# Altium schematic sheet Base_Module.SchDoc
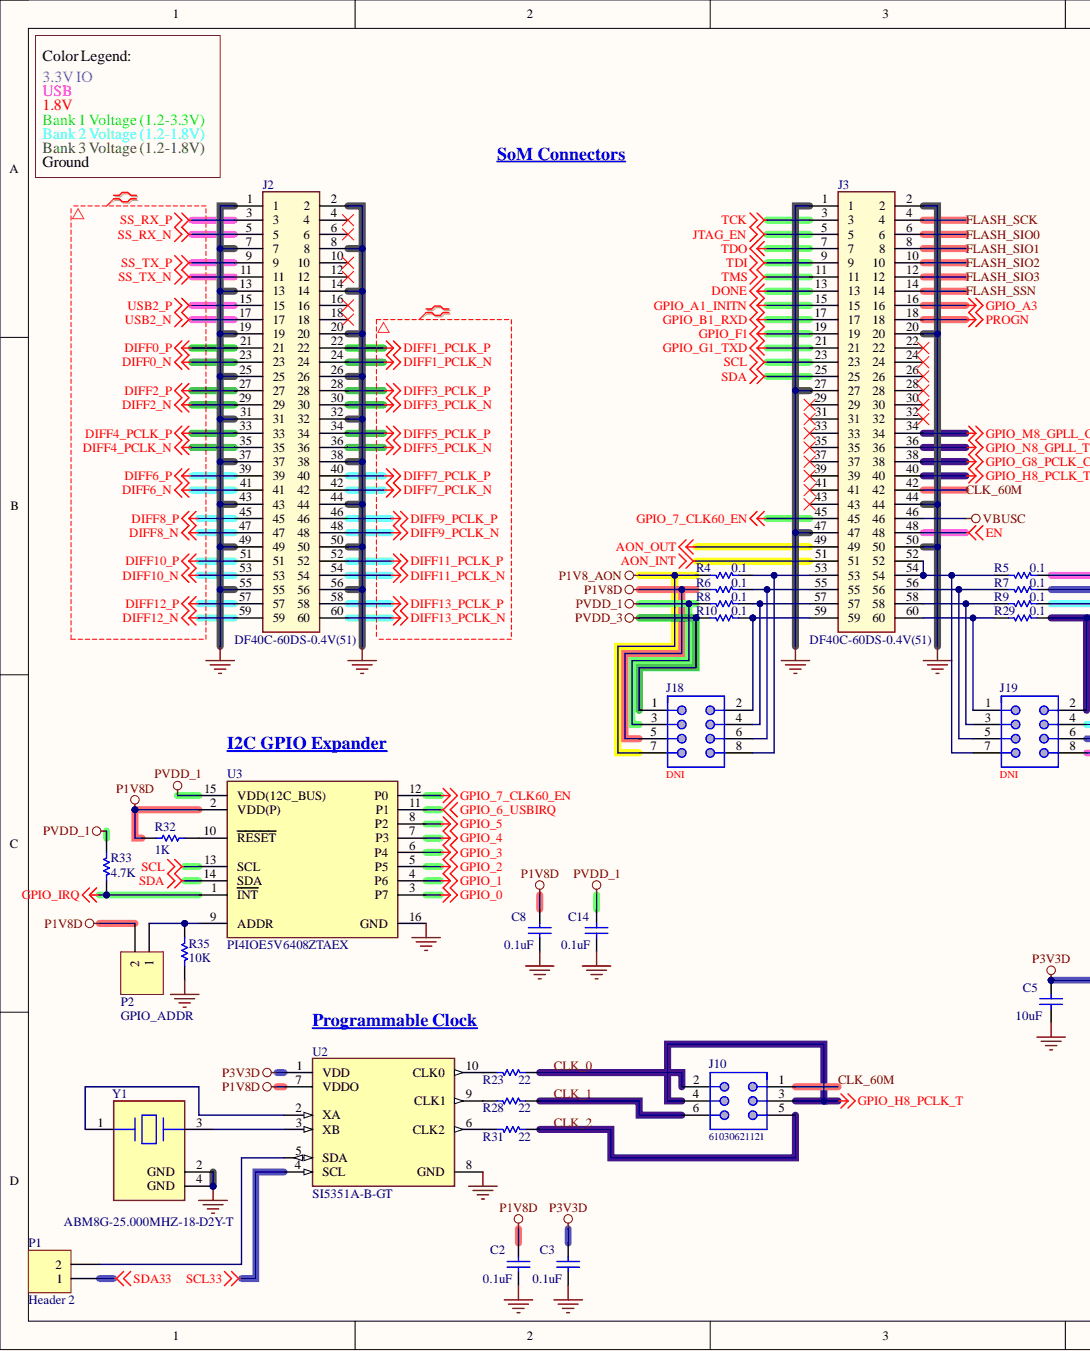
[diagram p0: Base_Module.SchDoc, left]
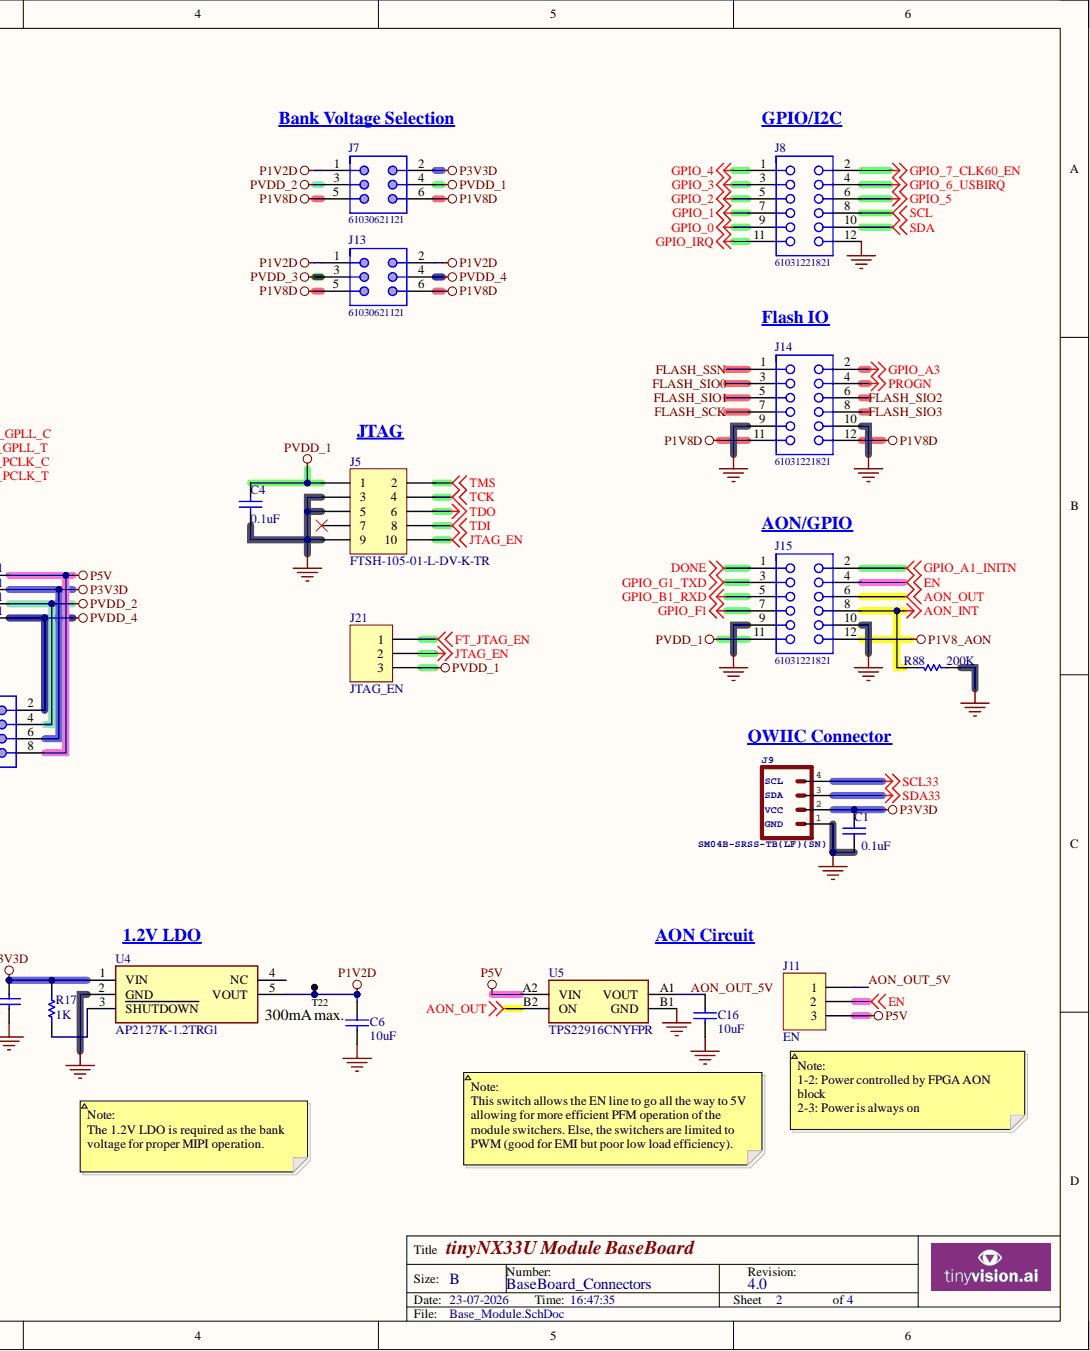
[diagram p1: Base_Module.SchDoc, right]

## Parts
C1  0.1uF  [CAPC1005X55USR]
C2  0.1uF  [CAPC0603X33N]
C3  0.1uF  [CAPC0603X33N]
C4  0.1uF  [CAPC1005X55USR]
C5  10uF  [CAPC1005X55USR]
C6  10uF  [CAPC1005X55USR]
C8  0.1uF  [CAPC0603X33N]
C14  0.1uF  [CAPC0603X33N]
C16  10uF  [CAPC1005X55USR]
J2  DF40C-60DS-0.4V(51)  [DF40C60DS04V51]
J3  DF40C-60DS-0.4V(51)  [DF40C60DS04V51]
J5  FTSH-105-01-L-DV-K-TR  [FTSH-105-01-XXX-DV-K]
J7  61030621121  [HDR3X2_MALE_2.54MM_WE61030621121]
J8  61031221821  [HDR6X2_MALE_2.54MM_WE61031221821]
J9  SM04B-SRSS-TB(LF)(SN)  [JST_SM04B-SRSS-TB(LF)(SN)]
J10  61030621121  [HDR3X2_MALE_2.54MM_WE61030621121]
J11  Content: EN  [HDR3X1_MALE_2.54MM]  (hidden comment: Header 3X1_2.54MM)
J13  61030621121  [HDR3X2_MALE_2.54MM_WE61030621121]
J14  61031221821  [HDR6X2_MALE_2.54MM_WE61031221821]
J15  61031221821  [HDR6X2_MALE_2.54MM_WE61031221821]
J18  DNI  [HDR4X2_MALE_2.54MM_WE61300821121]
J19  DNI  [HDR4X2_MALE_2.54MM_WE61300821121]
J21  Content: JTAG_EN  [HDR3X1_MALE_2.54MM]  (hidden comment: Header 3X1_2.54MM)
P1  Header 2  [HDR1X2]
P2  GPIO_ADDR  [HDR1X2]
R4  0.1  [RESC1005X40USR]
R5  0.1  [RESC1005X40USR]
R6  0.1  [RESC1005X40USR]
R7  0.1  [RESC1005X40USR]
R8  0.1  [RESC1005X40USR]
R9  0.1  [RESC1005X40USR]
R10  0.1  [RESC1005X40USR]
R17  1K  [RESC1005X40USR]
R23  22  [RESC1005X40USR]
R28  22  [RESC1005X40USR]
R29  0.1  [RESC1005X40USR]
R31  22  [RESC1005X40USR]
R32  1K  [RESC1005X40USR]
R33  4.7K  [RESC1005X40USR]
R35  10K  [RESC1005X40USR]
R88  200K  [RESC1005X40USR]
T22  TP_S  [TP_TH]  (value not drawn)  (no BOM)
U2  SI5351A-B-GT  [10MSOP_3X3_SIL]
U3  PI4IOE5V6408ZTAEX  [PI4IOE5V6408ZTAEX]  (value not drawn)
U4  AP2127K-1.2TRG1  [SOT95P282X145-5N]
U5  TPS22916CNYFPR  [BGA4C40P2X2_78X78X50]
Y1  ABM8G-25.000MHZ-18-D2Y-T  [XTAL_ABM8G3225X10]

## Nets
AON_INT: J3.51 J15.8 R88.2
AON_OUT: J3.49 J15.6 U5.B2(ON)
DIFF0_N: J2.23
DIFF0_P: J2.21
DIFF1_PCLK_N: J2.24
DIFF1_PCLK_P: J2.22
DIFF2_N: J2.29
DIFF2_P: J2.27
DIFF3_PCLK_N: J2.30
DIFF3_PCLK_P: J2.28
DIFF4_PCLK_N: J2.35
DIFF4_PCLK_P: J2.33
DIFF5_PCLK_N: J2.36
DIFF5_PCLK_P: J2.34
DIFF6_N: J2.41
DIFF6_P: J2.39
DIFF7_PCLK_N: J2.42
DIFF7_PCLK_P: J2.40
DIFF8_N: J2.47
DIFF8_P: J2.45
DIFF9_PCLK_N: J2.48
DIFF9_PCLK_P: J2.46
DIFF10_N: J2.53
DIFF10_P: J2.51
DIFF11_PCLK_N: J2.54
DIFF11_PCLK_P: J2.52
DIFF12_N: J2.59
DIFF12_P: J2.57
DIFF13_PCLK_N: J2.60
DIFF13_PCLK_P: J2.58
DONE: J3.13 J15.1
EN: J3.48 J11.2 J15.4
FT_JTAG_EN: J21.1
GND: C1.1 C2.1 C3.1 C4.1 C5.1 C6.1 C8.1 C14.1 C16.1 J2.1 J2.2 J2.7 J2.8 J2.13 J2.14 J2.19 J2.20 J2.25 J2.26 J2.31 J2.32 J2.37 J2.38 J2.43 J2.44 J2.49 J2.50 J2.55 J2.56 J3.1 J3.2 J3.20 J3.27 J3.44 J3.47 J3.50 J5.3 J5.5 J5.9 J8.12 J9.1 J14.9 J14.10 J15.9 J15.10 R35.1 R88.1 U2.8(GND) U3.16(GND) U4.2(GND) U5.B1(GND) Y1.2(GND) Y1.4(GND)
GPIO_0: J8.9 U3.3(P7)
GPIO_1: J8.7 U3.4(P6)
GPIO_2: J8.5 U3.5(P5)
GPIO_3: J8.3 U3.6(P4)
GPIO_4: J8.1 U3.7(P3)
GPIO_5: J8.6 U3.8(P2)
GPIO_6_USBIRQ: J8.4 U3.11(P1)
GPIO_7_CLK60_EN: J3.45 J8.2 U3.12(P0)
GPIO_A1_INITN: J3.15 J15.2
GPIO_A3: J3.16 J14.2
GPIO_B1_RXD: J3.17 J15.5
GPIO_F1: J3.19 J15.7
GPIO_G1_TXD: J3.21 J15.3
GPIO_G8_PCLK_C: J3.38
GPIO_H8_PCLK_T: J3.40 J10.3 J10.4
GPIO_IRQ: J8.11 R33.2 U3.1(INT)
GPIO_M8_GPLL_C: J3.34
GPIO_N8_GPLL_T: J3.36
JTAG_EN: J3.5 J5.10 J21.2
P1V2D: C6.2 J7.1 J13.1 J13.2 T22.1 U4.5(VOUT)
P1V8_AON: J15.12 J18.7 R4.2
P1V8D: C2.2 C8.2 J7.5 J7.6 J13.5 J13.6 J14.11 J14.12 J18.5 P2.2 R6.2 R32.1 U2.7(VDDO) U3.2(VDD(P))
P3V3D: C1.2 C3.2 C5.2 J7.2 J9.2 J19.6 R7.1 R17.1 U2.1(VDD) U4.1(VIN)
P5V: J11.3 J19.8 R5.1 U5.A2(VIN)
PROGN: J3.18 J14.4
PVDD_1: C4.2 C14.2 J5.1 J7.4 J15.11 J18.3 J21.3 R8.2 R33.1 U3.15(VDD(12C_BUS))
PVDD_2: J7.3 J19.4 R9.1
PVDD_3: J13.3 J18.1 R10.2
PVDD_4: J13.4 J19.2 R29.1
SCL: J3.23 J8.8 U3.13(SCL)
SCL33: J9.4 U2.4(SCL)
SDA: J3.25 J8.10 U3.14(SDA)
SDA33: J9.3 P1.1
SS_RX_N: J2.5
SS_RX_P: J2.3
SS_TX_N: J2.11
SS_TX_P: J2.9
TCK: J3.3 J5.4
TDI: J3.9 J5.8
TDO: J3.7 J5.6
TMS: J3.11 J5.2
USB2_N: J2.17
USB2_P: J2.15
VBUSC: J3.46
AON_OUT_5V: C16.2 J11.1 U5.A1(VOUT)
CLK_0: J10.2 R23.1
CLK_1: J10.6 R28.1
CLK_2: J10.5 R31.1
CLK_60M: J3.42 J10.1
FLASH_SCK: J3.4 J14.7
FLASH_SIO0: J3.6 J14.3
FLASH_SIO1: J3.8 J14.5
FLASH_SIO2: J3.10 J14.6
FLASH_SIO3: J3.12 J14.8
FLASH_SSN: J3.14 J14.1
NetJ3_52: J3.52 J3.54 J19.7 R5.2
NetJ3_53: J3.53 J18.8 R4.1
NetJ3_55: J3.55 J18.6 R6.1
NetJ3_56: J3.56 J19.5 R7.2
NetJ3_57: J3.57 J18.4 R8.1
NetJ3_58: J3.58 J19.3 R9.2
NetJ3_59: J3.59 J18.2 R10.1
NetJ3_60: J3.60 J19.1 R29.2
NetP1_2: P1.2 U2.5(SDA)
NetP2_1: P2.1 R35.2 U3.9(ADDR)
NetR17_2: R17.2 U4.3(SHUTDOWN)
NetR23_2: R23.2 U2.10(CLK0)
NetR28_2: R28.2 U2.9(CLK1)
NetR31_2: R31.2 U2.6(CLK2)
NetR32_2: R32.2 U3.10(RESET)
NetU2_2: U2.2(XA) Y1.1
NetU2_3: U2.3(XB) Y1.3

Not connected: J2 4 6 10 12 16 18; J3 22 24 26 28 29 30 31 32 33 35 37 39 41 43; J5 7; U4 4(NC)

## Library data of the parts
C1 C4 = CAP CER 0.1UF 16V X7R 0402: Manufacturer: TDK Corporation; Manufacturer Part Number: CGA2B1X7R1C104K050BC; Component Kind: Standard; Description: CAP CER 0.1UF 16V X7R 0402; Height: 0.55mm; Library Name: NX Module baseboard.SCHLIB; Package: 0402; Supplier 1: Digi-Key; Supplier Part Number 1: 445-5613-2-ND; value: 0.1uF
C2 C3 C8 C14 = CAP CER 0.1UF 10V X7S 0201: CAP CER 0.1UF 10V X5R 0201; Manufacturer: Murata Electronics; Manufacturer Part Number: GRM033R61A104KE15D; Component Kind: Standard; Height: 0.33mm; Library Name: Smart Camera v2.SCHLIB; Package: 0201; Supplier 1: Digi-Key; Supplier Part Number 1: 490-5881-1-ND; value: 0.1uF
C5 C6 C16 = Cap_10uF_X5R_20%_10V_0402: CAP CER 10UF 10V X5R 0402; Manufacturer: Samsung Electro-Mechanics; Manufacturer Part Number: CL05A106MP5NUNC; Part #: E-CAP-042; Component Kind: Standard; ComponentLink1Description: New link; Height: 0.55mm; Package: 0402; Supplier 1: Digi-Key; Supplier Part Number 1: 1276-1450-1-ND; value: 10uF; Library Name: tinyCLUNX33_Compute.SCHLIB
J2 J3 = DF40C-60DS-0.4V(51): CONN RCPT 60POS SMD GOLD; Manufacturer: Hirose; Connector Type: Receptacle; Current Rating: 300mA; Mated Stacking Heights: 1.5mm; Number of Contacts: 60; Pitch: 0.4mm; Voltage Rating: 30V; Category: Headers and Wire Housings; Component Kind: Standard; ComponentLink1Description: https://datasheet.ciiva.com/46408/1824826-46408909.pdf?src-supplier=Element14; ComponentLink1URL: https://datasheet.ciiva.com/46408/1824826-46408909.pdf?src-supplier=Element14; Contact Material: Bronze; Contact Plating: Gold; Gender: Receptacle; Housing Material: Polymer; Lead Free: Lead Free; Library Name: NX Module baseboard.SCHLIB; Manufacturer Part Number: DF40C-60DS-0.4V(51); Mounting Technology: Surface Mount; Number of Positions: 60; Number of Rows: 2; Package Quantity: 5000; Packaging: Tape and Reel; Pricing: 1=1.29000, 10=1.08900, 25=1.04440, 50=1.02220, 100=0.97770, 250=0.88880, 500=0.79992, 1000=0.71104, 2500=0.64438 (USD); Reach SVHC Compliant: No; RoHS: Yes; RoHS Compliant: Yes; Series: DF40; Stock: 11621; Supplier 1: Digi-Key; Supplier Part Number 1: 26-DF40C-60DS-0.4V(51)CT-ND; Termination: SMD/SMT
J5 = HDR2X5_1.27mm: CONN HEADER SMD 10POS 1.27MM; Manufacturer: Samtec Inc.; Connector Type: Male Pin; Current Rating: 1.75A; Gender: Male; Number of Contacts: 10; Row Spacing: 0.05inch; Category: Headers and Wire Housings; Color: Black; Component Kind: Standard; ComponentLink1Description: https://datasheet.ciiva.com/10245/ftsh-mt-10245289.pdf?src-supplier=Avnet; ComponentLink1URL: https://datasheet.ciiva.com/10245/ftsh-mt-10245289.pdf?src-supplier=Avnet; ComponentLink2Description: https://datasheet.ciiva.com/739/ftsh-1xx-xx-xxx-dv-xxx-mkt-739005.pdf?src-supplier=Digi-Key; ComponentLink2URL: https://datasheet.ciiva.com/739/ftsh-1xx-xx-xxx-dv-xxx-mkt-739005.pdf?src-supplier=Digi-Key; ComponentLink3Description: https://datasheet.ciiva.com/23735/81359-23735917.pdf?src-supplier=Newark; ComponentLink3URL: https://datasheet.ciiva.com/23735/81359-23735917.pdf?src-supplier=Newark; Contact Material: Bronze; Contact Mating Length: 0.12inch; Contact Plating: Gold; Contact Type: Male Pin; Depth: 3.43mm; Housing Color: Black; Housing Material: Polymer; Lead Free: Lead Free; Length: 6.35mm; Library Name: tinyAI.SCHLIB; Manufacturer Part Number: FTSH-105-01-L-DV-K-TR; Max Current Rating: 1.75A; Max Operating Temperature: 125°C; Min Operating Temperature: -55°C; Mount: Surface Mount; Number of Positions: 10; Number of Rows: 2; Orientation: Straight; Package Quantity: 550; Packaging: Tape and Reel; Pitch: 1.27mm; Pricing 1: 1=4.28000, 10=3.88500, 100=3.42300 (USD); Radiation Hardening: No; REACH SVHC: No SVHC; RoHS: Yes; RoHS Compliant: Yes; Series: FTSH; Stock 1: 18878; Supplier 1: Digi-Key; Supplier Part Number 1: SAM13165CT-ND; Termination: Solder
J7 J10 J13 = Header 3X2_SMD_61030621121: SMT Vertical Pin Header WR-PHD, Pitch 2.54 mm, Dual Row, 6 pins; Manufacturer: Wurth Electronics; Contact Resistance: 20mR; Current Rating: 3A; Gender: Male; Number of Contacts: 6; Operating Supply Voltage: 250V; Pitch: 0.1inch; Category: Headers and Wire Housings; Color: Black; Component Kind: Standard; ComponentLink1Description: https://datasheet.ciiva.com/28505/1737273-28505153.pdf?src-supplier=Element14; ComponentLink1URL: https://datasheet.ciiva.com/28505/1737273-28505153.pdf?src-supplier=Element14; Connector Type: Header; Contact Finish - Mating: GOLD; Contact Material: Copper Alloy,Copper; Contact Mating Length: 0.236inch; Contact Plating: Gold; Contact Shape: Square; Contact Type: Male Pin; Fastening Type: Pull; Flammability Rating: UL94 V-0; Height: 10mm; Insulation Color: Black; Insulation Material: Nylon; Library Name: NX Module baseboard.SCHLIB; Manufacturer Part Number: 61030621121; Max Operating Temperature: 125°C; Min Operating Temperature: -40°C; Mount: Surface Mount; Number of Positions: 6; Number of Rows: 2; Orientation: 5495OrientationType; Packaging: Cut Tape; Pricing 1: 1=1.84000, 10=1.55900, 25=1.30000, 50=1.08400, 100=0.98500 (USD); Reach SVHC Compliant: Unknown; RoHS: Yes; RoHS Compliant: Yes; Row Spacing: 0.1inch; Series: WR-PHD; Stock 1: 2240; Supplier 1: Digikey; Supplier Part Number 1: 732-61030621121CT-ND; Termination: Solder; Voltage Rating: 250V
J8 J14 J15 = Header 6X2_SMD_WE61031221821: Connector, RCPT, 12POS, 2ROW, 2.54mm;; Manufacturer: Wurth Electronics; Connector Type: Header; Number of Positions: 12; Voltage Rating (AC): 250V; Category: Connectors; Component Kind: Standard; Contact Finish - Mating: GOLD; Contact Material: Copper Alloy; Contact Shape: Square; Contact Type: Female Socket; Fastening Type: Pull; Flammability Rating: UL94 V-0; Insulation Color: Black; Insulation Material: Nylon; Library Name: NX Module baseboard.SCHLIB; Manufacturer Part Number: 61031221821; Max Operating Temperature: 105°C; Min Operating Temperature: -40°C; Mount: Surface Mount; Number of Rows: 2; Pricing 1: 1=3.07000, 10=2.85700, 50=2.55400 (USD); RoHS: Yes; RoHS Compliant: Yes; Series: WR-PHD; Stock 1: 530; Supplier 1: Digikey; Supplier Part Number 1: 732-13383-1-ND; Termination: Solder
J9 = QWIIC_CONNECTORJS-1MM: Connector Header, Side Entry, SR Series, 4 Position, 1.00mm, 50V, Surface Mount, Tin, Natural; Manufacturer: JST; Contact Resistance: 40mR; Max Current Rating: 1A; Number of Contacts: 4; Pitch: 1mm; Category: Connectors; Component Kind: Standard; ComponentLink1Description: https://datasheet.ciiva.com/26894/getdatasheetpartid-640736-26894676.pdf?src-supplier=Verical; ComponentLink1URL: https://datasheet.ciiva.com/26894/getdatasheetpartid-640736-26894676.pdf?src-supplier=Verical; ComponentLink2Description: https://datasheet.ciiva.com/26894/getdatasheetpartid-499363-26894945.pdf?src-supplier=Verical; ComponentLink2URL: https://datasheet.ciiva.com/26894/getdatasheetpartid-499363-26894945.pdf?src-supplier=Verical; ComponentLink3Description: https://datasheet.ciiva.com/16487/jstsm04b-srss-tb-lf-sn-16487222.pdf?src-supplier=Future+Electronics; ComponentLink3URL: https://datasheet.ciiva.com/16487/jstsm04b-srss-tb-lf-sn-16487222.pdf?src-supplier=Future+Electronics; Connector Type: Header; Contact Finish - Mating: TIN; Contact Material: Copper Alloy; Contact Plating: Copper,Tin; Contact Shape: Square; Contact Type: Male Pin; Depth: 4.95mm; Fastening Type: Pull; Flammability Rating: UL94 V-0; GND: GND; Housing Color: Beige; Housing Material: Polyamide; Insulation Color: Natural; Insulation Material: Nylon; Lead Free: Lead Free; Length: 6mm; Library Name: NX Module baseboard.SCHLIB; Manufacturer Part Number: SM04B-SRSS-TB(LF)(SN); Max Operating Temperature: 85°C; Max Voltage Rating (AC): 50V; Max Voltage Rating (DC): 50V; Min Operating Temperature: -25°C; Mount: Surface Mount; Number of Positions: 4; Number of Rows: 1; Orientation: Right Angle; Packaging: Tape and Reel; Pricing 1: 1=0.141000, 10=0.141000, 100=0.141000, 500=0.141000, 1000=0.141000 (USD); RoHS: Yes; SCL: SCL; SDA: SDA; Series: SR; Stock 1: 176593; Supplier 1: Newark; Supplier Part Number 1: 87R0314; Termination: Solder; VCC: VCC; Voltage Rating: 50V
J11 J21 = Header 3X1_2.54MM: CONN HEADER .100 SNGL STR 3POS; Manufacturer: Sullins; Manufacturer Part Number: PRPC003SAAN-RC; Number of Positions: 3; Pitch: 0.1inch; Category: Connectors; Color: Black; Component Kind: Standard; ComponentLink1Description: https://datasheet.ciiva.com/741/xrxczzzsxxn-rc-st-11635-b-741242.pdf?src-supplier=Digi-Key; ComponentLink1URL: https://datasheet.ciiva.com/741/xrxczzzsxxn-rc-st-11635-b-741242.pdf?src-supplier=Digi-Key; Contact Mating Length: 0.23inch; Contact Plating: Gold; Contact Type: Male Pin; Library Name: NX Module baseboard.SCHLIB; Mounting Technology: Through Hole; Number of Rows: 1; Package Quantity: 1; Packaging: Bulk; Pricing: 1=0.12000, 10=0.10900, 25=0.08120, 50=0.06960, 100=0.06730, 250=0.05804, 500=0.05572 (USD); RoHS: Yes; RoHS Compliant: Yes; Series: -; Stock: 16156; Supplier 1: Digi-Key; Supplier Part Number 1: S1011EC-03-ND; Termination: Solder
J18 J19 = Header 4X2_2.54MM: Header, 2.54mm Pitch, THT, Dual Pin, 8 Pins, 3A, 500VAC, WR-PHD | Wurth Electronics 61300821121; Contact Resistance: 20mR; Gender: Male; Number of Contacts: 8; Operating Supply Voltage: 250V; Pitch: 0.1inch; Category: Headers and Wire Housings; Color: Black; Component Kind: Standard; ComponentLink1Description: https://datasheet.ciiva.com/28505/1442180-28505510.pdf?src-supplier=Element14; ComponentLink1URL: https://datasheet.ciiva.com/28505/1442180-28505510.pdf?src-supplier=Element14; Contact Material: Copper; Contact Mating Length: 0.236inch; Contact Plating: Gold; Contact Type: Male Pin; Current Rating: 3A; Height: 8.89mm; Lead Free: Lead Free; Library Name: NX Module baseboard.SCHLIB; Manufacturer: Wurth Electronics; Manufacturer Part Number: 61300821121; Max Operating Temperature: 105°C; Min Operating Temperature: -40°C; Mount: Through Hole; Number of Positions: 8; Number of Rows: 2; Orientation: 5495OrientationType; Packaging: Bulk; Pricing 1: 1=0.71400, 10=0.60500, 25=0.50400, 50=0.42100, 100=0.38300 (USD); Reach SVHC Compliant: Unknown; RoHS: Yes; RoHS Compliant: Yes; Row Spacing: 0.1inch; Series: WR-PHD; Stock 1: 1861; Supplier 1: Newark; Supplier Part Number 1: 20X0965; Termination: Solder; Voltage Rating: 250V
P1 P2 = Header 2: Header, 2-Pin; Manufacturer: Amphenol ICC (FCI); Manufacturer Part Number: 68001-202HLF; Component Kind: Standard; LatestRevisionDate: 17-Jul-2002; LatestRevisionNote: Re-released for DXP Platform.; Library Name: Miscellaneous Connectors.IntLib; Signal Integrity: Connector; Supplier Part Number 1: 609-3469-ND; Publisher: Altium Limited
R4-R10 R29 = RES 0.5 OHM 1% 1/8W 0402: RES 0.1 OHM 1% 1/8W 0402; Manufacturer: Stackpole Electronics Inc; Manufacturer Part Number: CSR0402FKR100; Component Kind: Standard; Height: 0.43mm; Library Name: tinyAI.SCHLIB; Package: 0402; Supplier 1: Digi-Key; Supplier Part Number 1: CSR0402FKR100CT-ND; value: 0.1
R17 R32 = RES 1K OHM 1% 1/16W 0402: RES SMD 1K OHM 1% 1/16W 0402; Manufacturer: YAGEO; Manufacturer Part Number: RC0402FR-071KL; Component Kind: Standard; Height: 0.4mm; Package: 0402; Supplier 1: Digi-Key; Supplier Part Number 1: 311-1.00KLRCT-ND; value: 1K; Library Name: Tiger_Ti180.SCHLIB
R23 R28 R31 = Res_10K_1%_0402: RES 22 OHM 1% 1/16W 0402; Manufacturer: Yageo; Manufacturer Part Number: RC0402FR-0722RL; Component Kind: Standard; Height: 0.4mm; Package: 0402; Supplier 1: Digi-Key; Supplier Part Number 1: 311-22.0LRCT-ND; value: 22; Library Name: MCD_Temp_LBE.SchLib
R33 = RES 4.7K OHM 1% 1/16W 0402: RES SMD 4.7K OHM 1% 1/16W 0402; Manufacturer: Stackpole Electronics Inc; Manufacturer Part Number: RMCF0402FT4K70; Component Kind: Standard; Height: 0.4mm; Package: 0402; Supplier 1: Digi-Key; Supplier Part Number 1: RMCF0402FT4K70TR-ND; value: 4.7K; Library Name: Tiger_Ti180.SCHLIB
R35 = RES SMD 10K OHM 1% 1/16W 0402: Manufacturer: Samsung Electro-Mechanics; Manufacturer Part Number: RC1005F103CS; Component Kind: Standard; Description: RES SMD 10K OHM 1% 1/16W 0402; Height: 0.4mm; Library Name: NX Module baseboard.SCHLIB; Package: 0402; Supplier 1: Digi-Key; Supplier Part Number 1: 1276-3431-2-ND; value: 10K
R88 = Res_10K_1%_0402: RES SMD 200K OHM 1% 1/16W 0402; Manufacturer: Stackpole Electronics Inc; Manufacturer Part Number: RMCF0402FT200K; Component Kind: Standard; Height: 0.4mm; Package: 0402; Supplier 1: Digi-Key; Supplier Part Number 1: RMCF0402FT200KTR-ND; value: 200K; Library Name: MCD_Temp_LBE.SchLib
T22 = TP_S: test point; Library Name: AiC.SCHLIB
U2 = 107df8f5b0a702abb30a0423679c576: SILICON LABS - SI5351A-B-GTR - Générateur d'horloge Programmable, 200MHz, Alim. 2,25V à 3.6V, 3 sorties, MSOP-10; Input: Crystal; Max Duty Cycle: 55%; Number of Circuits: 2; Number of Outputs: 3; Operating Supply Current: 22mA; Operating Supply Voltage: 3.3V; PLL: Yes; Case/Package: MSOP; Category: Clock Generators, PLLs, Frequency Synthesizers; Clock Frequency: 200MHz; Component Kind: Standard; ComponentLink1Description: http://www.farnell.com/datasheets/2802507.pdf?src-supplier=Farnell; ComponentLink1URL: http://www.farnell.com/datasheets/2802507.pdf?src-supplier=Farnell; ComponentLink2Description: http://www.mouser.com/ds/2/368/Si5351-B-346869.pdf?src-supplier=Mouser; ComponentLink2URL: http://www.mouser.com/ds/2/368/Si5351-B-346869.pdf?src-supplier=Mouser; ComponentLink3Description: https://www.silabs.com/Support%20Documents/TechnicalDocs/Si5351-B.pdf?src-supplier=Avnet; ComponentLink3URL: https://www.silabs.com/Support%20Documents/TechnicalDocs/Si5351-B.pdf?src-supplier=Avnet; ComponentLink4Description: https://www.silabs.com/documents/public/data-sheets/Si5351-B.pdf?src-supplier=Digi-Key; ComponentLink4URL: https://www.silabs.com/documents/public/data-sheets/Si5351-B.pdf?src-supplier=Digi-Key; ComponentLink5Description: https://www.silabs.com/Support%20Documents/TechnicalDocs/Si5350-51-short.pdf?src-supplier=Digi-Key; ComponentLink5URL: https://www.silabs.com/Support%20Documents/TechnicalDocs/Si5350-51-short.pdf?src-supplier=Digi-Key; ComponentLink6Description: http://www.farnell.com/datasheets/2802507.pdf?src-supplier=Newark; ComponentLink6URL: http://www.farnell.com/datasheets/2802507.pdf?src-supplier=Newark; Library Name: NX Module.SCHLIB; Manufacturer: Silicon Labs; Manufacturer Part Number: SI5351A-B-GTR; Max Operating Temperature: 85°C; Min Operating Temperature: -40°C; Mounting Technology: Surface Mount; Package Quantity: 2500; Packaging: Tape and Reel; Pins: 10; Pricing: 1=1.14000, 10=0.96500, 50=0.93600, 100=0.91500 (GBP); RoHS: Yes; RoHS Compliant: Yes; Series: MultiSynth™; Supplier 1: Farnell; Supplier Part Number 1: 3105964
U3 = PI4IOE5V6408ZTAEX: Integrated Circuit; Manufacturer_Name: Diodes Incorporated; Arrow Part Number: PI4IOE5V6408ZTAEX; Arrow Price/Stock: https://www.arrow.com/en/products/pi4ioe5v6408ztaex/diodes-incorporated?region=asia; Component Kind: Standard; Datasheet Link: https://www.diodes.com/assets/Datasheets/PI4IOE5V6408.pdf; Height: 0.55mm; Library Name: NX Module baseboard.SchLib; Manufacturer_Part_Number: PI4IOE5V6408ZTAEX; Mouser Part Number: 729-I4IOE5V6408ZTAEX; Mouser Price/Stock: https://www.mouser.co.uk/ProductDetail/Diodes-Incorporated/PI4IOE5V6408ZTAEX?qs=1mbolxNpo8d6bZFWmZ9pcg%3D%3D
U4 = AP2127K-1.8TRG1: Linear Voltage Regulator IC Positive Fixed 1 Output 300mA SOT-23-5; Manufacturer_Name: Diodes Incorporated; Geometry.Height: 1.45mm; JLPCB PN: C151376; Arrow Part Number: AP2127K-1.8TRG1; Arrow Price/Stock: https://www.arrow.com/en/products/ap2127k-1.8trg1/diodes-incorporated?region=nac; Component Kind: Standard; Datasheet Link: https://www.diodes.com//assets/Datasheets/AP2127.pdf; Library Name: AP2127K-1.8TRG1.SchLib; Manufacturer_Part_Number: AP2127K-1.8TRG1; Mouser Part Number: 621-AP2127K-1.8TRG1; Mouser Price/Stock: https://www.mouser.co.uk/ProductDetail/Diodes-Incorporated/AP2127K-1.8TRG1?qs=x6A8l6qLYDDmU4WXw4%252BBlA%3D%3D
U5 = TPS22916CNYFPR: TPS22916 5.5-V, 2-A Ultra-Low Leakage Load Switch; Manufacturer_Name: Texas Instruments; Geometry.Height: 0.5mm; JLPCB PN: C2655476; Arrow Part Number: TPS22916CNYFPR; Arrow Price/Stock: https://www.arrow.com/en/products/tps22916cnyfpr/texas-instruments?utm_currency=USD&region=nac; Component Kind: Standard; Datasheet Link: http://www.ti.com/lit/gpn/tps22916; Library Name: TPS22916CNYFPR.SchLib; Manufacturer_Part_Number: TPS22916CNYFPR; Mouser Part Number: 595-TPS22916CNYFPR; Mouser Price/Stock: https://www.mouser.co.uk/ProductDetail/Texas-Instruments/TPS22916CNYFPR?qs=1mbolxNpo8fckcJJ07ZkSQ%3D%3D
Y1 = 48e526d86b7f2615d98721063c72f49: Crystal 25MHz ±20ppm (Tol) ±30ppm (Stability) 18pF FUND 60Ohm 4-Pin Ultra Mini-CSMD T/R; Manufacturer: Abracon; ESR: 60R; Case/Package: CSMD; Category: Crystals; Component Kind: Standard; ComponentLink1Description: https://datasheet.ciiva.com/15116/getdatasheetpartid-719795-15116706.pdf?src-supplier=Verical; ComponentLink1URL: https://datasheet.ciiva.com/15116/getdatasheetpartid-719795-15116706.pdf?src-supplier=Verical; ComponentLink2Description: https://datasheet.ciiva.com/860/abm8g-860745.pdf?src-supplier=Digi-Key; ComponentLink2URL: https://datasheet.ciiva.com/860/abm8g-860745.pdf?src-supplier=Digi-Key; ComponentLink3Description: https://datasheet.ciiva.com/860/abm8g-860746.pdf?src-supplier=Digi-Key; ComponentLink3URL: https://datasheet.ciiva.com/860/abm8g-860746.pdf?src-supplier=Digi-Key; ComponentLink4Description: https://datasheet.ciiva.com/860/abm8g-860747.pdf?src-supplier=Digi-Key; ComponentLink4URL: https://datasheet.ciiva.com/860/abm8g-860747.pdf?src-supplier=Digi-Key; ComponentLink5Description: https://datasheet.ciiva.com/3176/abm8g-3176077.pdf?src-supplier=Avnet; ComponentLink5URL: https://datasheet.ciiva.com/3176/abm8g-3176077.pdf?src-supplier=Avnet; ComponentLink6Description: https://datasheet.ciiva.com/7998/abm8-7998634.pdf?src-supplier=Element14; ComponentLink6URL: https://datasheet.ciiva.com/7998/abm8-7998634.pdf?src-supplier=Element14; ComponentLink7Description: https://datasheet.ciiva.com/28508/1883677-28508583.pdf?src-supplier=Element14; ComponentLink7URL: https://datasheet.ciiva.com/28508/1883677-28508583.pdf?src-supplier=Element14; Depth: 2.5mm; ESR (Equivalent Series Resistance): 60R; Frequency: 25MHz; Frequency Stability: 30ppm; Frequency Tolerance: 20ppm; Height: 0.039inch; Lead Free: Lead Free; Length: 3.2mm; Library Name: NX Module.SCHLIB; Load Capacitance: 18pF; Manufacturer Part Number: ABM8G-25.000MHZ-18-D2Y-T; Max Operating Temperature: 85°C; Min Operating Temperature: -40°C; Mounting Technology: Surface Mount; Operating Frequency: 25 MHz; Package Quantity: 1000; Packaging: Tape and Reel; Pins: 4; Pricing: 1000=0.24370, 2000=0.22880, 4000=0.22080, 6000=0.21970 (USD); Radiation Hardening: No; Reach SVHC Compliant: Unknown; RoHS: Yes; Series: ABM8G; Stock: 31000; Supplier 1: Arrow Electronics; Supplier Part Number 1: ABM8G-25.000MHZ-18-D2Y-T; Termination: SMD/SMT; Tolerance: 20ppm; Width: 0.098inch
